# Altium schematic sheet ESP32_WROOM2.SchDoc
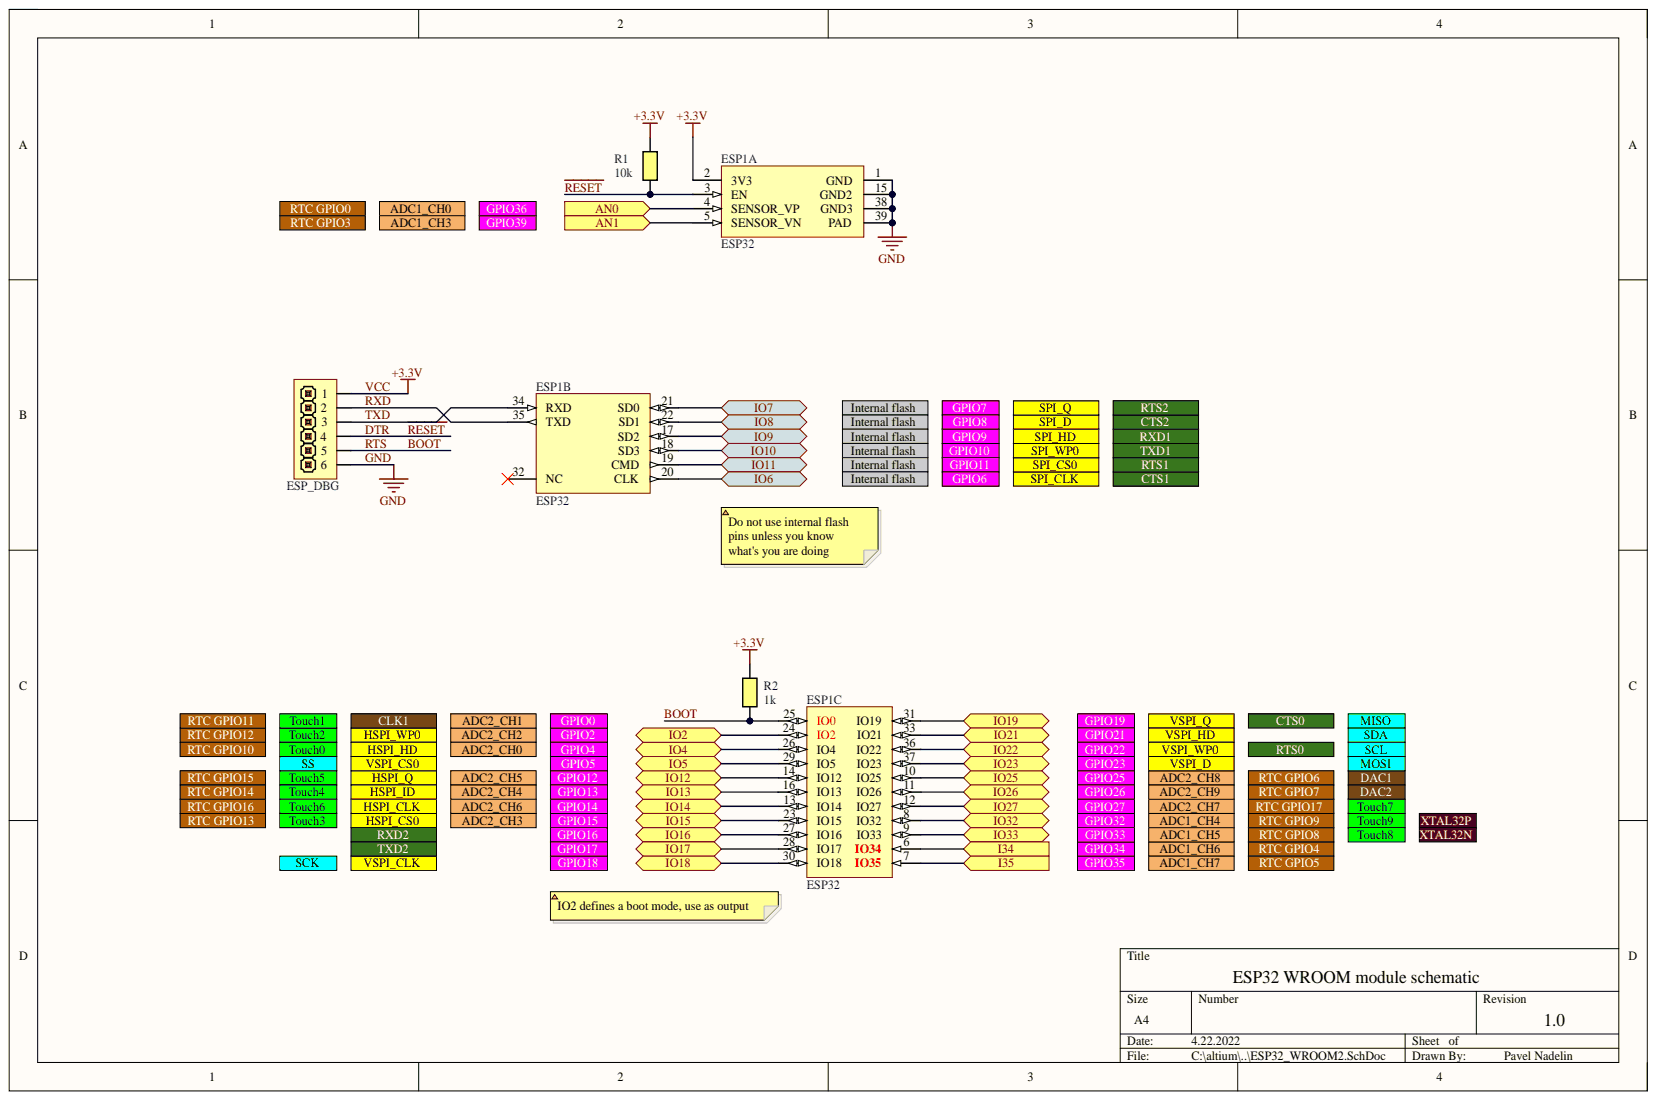
[diagram p0: ESP32_WROOM2.SchDoc]

## Parts
ESP1  ESP32  [ESP32-WROOM]  (parts A,B,C)
ESP_DBG  PIN HEADER 1x6  [PIN HEADER SINGLE 6]  (value not drawn)
R1  10k  [RESC0603(1608)_N]
R2  1k  [RESC0603(1608)_N]

## Ports
AN0, AN1, I34, I35, IO2, IO4, IO5, IO6, IO7, IO8, IO9, IO10, IO11, IO12, IO13, IO14, IO15, IO16, IO17, IO18, IO19, IO21, IO22, IO23, IO25, IO26, IO27, IO32, IO33

## Nets
+3.3V: ESP1.2(3V3) ESP_DBG.1 R1.1 R2.1
AN0: ESP1.4(SENSOR_VP) ; port AN0
AN1: ESP1.5(SENSOR_VN) ; port AN1
BOOT: ESP1.25(IO0) ESP_DBG.5 R2.2
DTR: ESP1.3(EN) ESP_DBG.4 R1.2
GND: ESP1.1(GND) ESP1.15(GND2) ESP1.38(GND3) ESP1.39(PAD) ESP_DBG.6
I34: ESP1.6(IO34) ; port I34
I35: ESP1.7(IO35) ; port I35
IO2: ESP1.24(IO2) ; port IO2
IO4: ESP1.26(IO4) ; port IO4
IO5: ESP1.29(IO5) ; port IO5
IO6: ESP1.20(CLK) ; port IO6
IO7: ESP1.21(SD0) ; port IO7
IO8: ESP1.22(SD1) ; port IO8
IO9: ESP1.17(SD2) ; port IO9
IO10: ESP1.18(SD3) ; port IO10
IO11: ESP1.19(CMD) ; port IO11
IO12: ESP1.14(IO12) ; port IO12
IO13: ESP1.16(IO13) ; port IO13
IO14: ESP1.13(IO14) ; port IO14
IO15: ESP1.23(IO15) ; port IO15
IO16: ESP1.27(IO16) ; port IO16
IO17: ESP1.28(IO17) ; port IO17
IO18: ESP1.30(IO18) ; port IO18
IO19: ESP1.31(IO19) ; port IO19
IO21: ESP1.33(IO21) ; port IO21
IO22: ESP1.36(IO22) ; port IO22
IO23: ESP1.37(IO23) ; port IO23
IO25: ESP1.10(IO25) ; port IO25
IO26: ESP1.11(IO26) ; port IO26
IO27: ESP1.12(IO27) ; port IO27
IO32: ESP1.8(IO32) ; port IO32
IO33: ESP1.9(IO33) ; port IO33
RXD: ESP1.35(TXD) ESP_DBG.2
TXD: ESP1.34(RXD) ESP_DBG.3

Not connected: ESP1 32(NC)

## Library data of the parts
ESP1 = ESP32S: ESP32-WROOM-32 is a powerful, generic Wi-Fi+BT+BLE MCU module; Component Kind: Standard; Library Name: Modules.SchLib
ESP_DBG = PIN HEADER 2.54 1x6: Component Kind: Standard; Library Name: Connectors_DEBUG_Testpoints.SchLib
R1 R2 = Resistor M: Resistor Medium Density; Component Kind: Standard; Library Name: Discrete_Passive_RCL.SchLib; Simulation: Model Name
  R1: Value: 10k
  R2: Value: 1k
